# Altium schematic sheet ExtStm.SchDoc
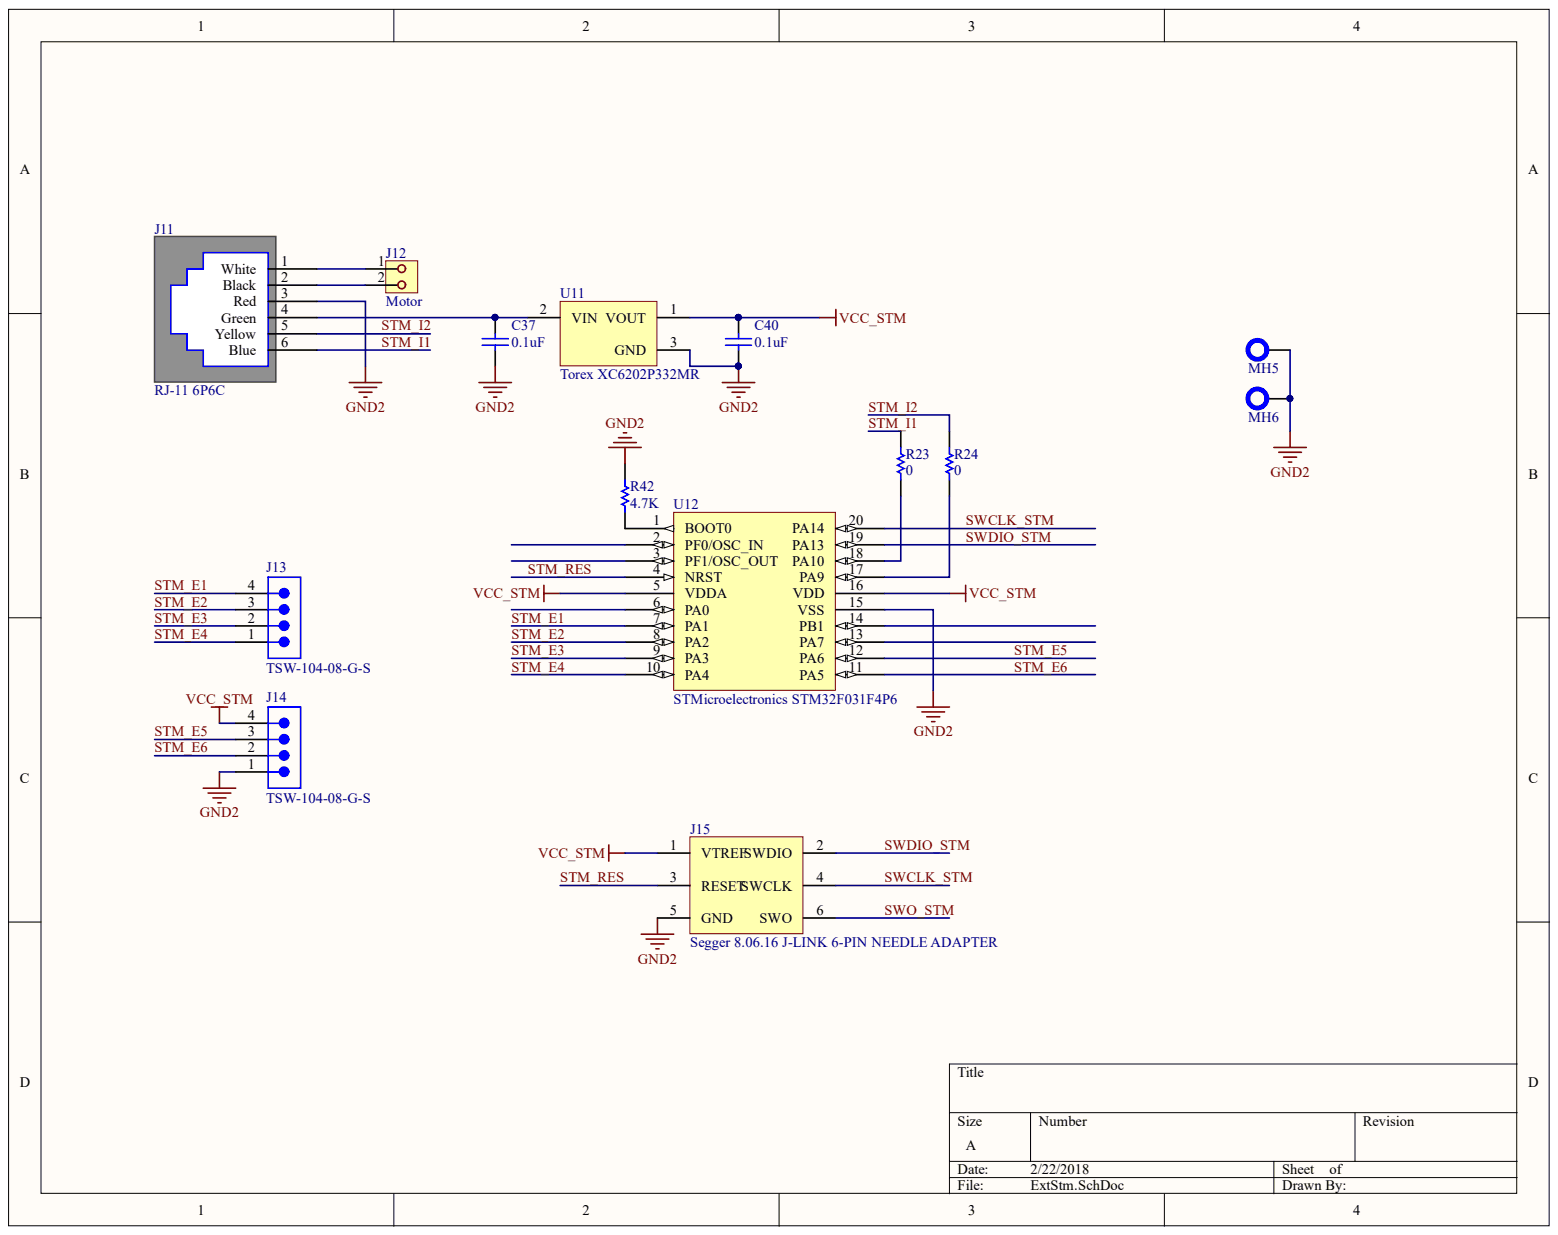
[diagram p0: ExtStm.SchDoc]

## Parts
C37  Capacitance: 0.1uF  [CAPC1608X90X35LL15T15]  (hidden comment: AVX Interconnect / Elco 06036D105KAT2A)
C40  Capacitance: 0.1uF  [CAPC1608X90X35LL15T15]  (hidden comment: AVX Interconnect / Elco 06036D105KAT2A)
J11  RJ-11 6P6C  [PCB-dhj0y0hoiu0232y63q4o-1]
J12  Motor  [PCB-55llon6gpk2h6a06nz0l-1]
J13  TSW-104-08-G-S  [SMTC-TSW-104-08-X-S]
J14  TSW-104-08-G-S  [SMTC-TSW-104-08-X-S]
J15  Segger 8.06.16 J-LINK 6-PIN NEEDLE ADAPTER  [PCB-ya76044v471n1vts2f97-1]
MH5  MountHole  [PCB-d0jqlyxt4wqhvgbnry4h-1]  (value not drawn)
MH6  MountHole  [PCB-d0jqlyxt4wqhvgbnry4h-1]  (value not drawn)
R23  Vishay Dale CRCW0603200KFKEA  [RESC1609X50X30ML10T20]  (value not drawn)
R24  Vishay Dale CRCW0603200KFKEA  [RESC1609X50X30ML10T20]  (value not drawn)
R42  Resistance: 4.7K  [RESC1609X50X30ML10T20]  (hidden comment: Vishay Dale CRCW0603200KFKEA)
U11  Torex XC6202P332MR  Manufacturer Part Number: XC6202P332MR  [PCB-0zngv7hxvzmz180uw1w7-1]
U12  STMicroelectronics STM32F031F4P6  [stm32f031f4p6]

## Nets
GND2: C37.1 C40.1 J11.3(Red) J14.1 J15.5(GND) MH5.1 MH6.1 R42.1 U11.3 U12.15(VSS)
VCC_STM: C40.2 J14.4 J15.1(VTREF) U11.1 U12.5(VDDA) U12.16(VDD)
STM_E1: J13.4 U12.7(PA1)
STM_E2: J13.3 U12.8(PA2)
STM_E3: J13.2 U12.9(PA3)
STM_E4: J13.1 U12.10(PA4)
STM_E5: J14.3 U12.12(PA6)
STM_E6: J14.2 U12.11(PA5)
STM_I1: J11.6(Blue) R23.2
STM_I2: J11.5(Yellow) R24.2
STM_RES: J15.3(RESET) U12.4(NRST)
SWCLK_STM: J15.4(SWCLK) U12.20(PA14)
SWDIO_STM: J15.2(SWDIO) U12.19(PA13)
SWO_STM: J15.6(SWO)
NetC37_2: C37.2 J11.4(Green) U11.2
NetJ11_1: J11.1(White) J12.1
NetJ11_2: J11.2(Black) J12.2
NetR23_1: R23.1 U12.18(PA10)
NetR24_1: R24.1 U12.17(PA9)
NetR42_2: R42.2 U12.1(BOOT0)

Not connected: U12 2(PF0/OSC_IN) 3(PF1/OSC_OUT) 6(PA0) 13(PA7) 14(PB1)

## Library data of the parts
C37 C40 = CMP-126022-2: CAP CER 1UF 6.3V 10% X5R 0603; Dielectric Material: Ceramic Multilayer; Insulation Resistance: 1e+11 Ω; Lead-Free Status: Lead Free; Capacitance Tolerance: ±10%; Case/Package: 0603; Component Kind: Standard; Dielectric Characteristic: X5R; Library Name: CommunityVault; Mounting Style: Surface Mount; Number of Pins: 2; OctopartID: 12fddbf79c04cd3a; Octopart Url: https://octopart.com/06036d105kat2a-avx+interconnect+%2F+elco-43935; REACH SVHC Compliance: No SVHC; RoHS: Compliant; Size-Height: 0.00086 m; Size-Length: 0.0016 m; Size-Thickness: 0.0008636 m; Size-Width: 0.00081 m; Voltage Rating (DC): 6.3 V
J11 = CMP-33ecfa0a2b0dec2b-2: Conn RJ-11 F 6 POS 1.02mm Solder RA Thru-Hole 6 Terminal 1 Port; Manufacturer Part Number: PT-J623SS-66GR; Component Kind: Standard; Library Name: CommunityVault; Lifecycle Status: Not Listed by Manufacturer; OctopartID: 33ecfa0a2b0dec2b; RoHS: Compliant
J12 = CMP-8fae46aeaafe5069-11: 2.54mm (.100") Pitch C-Grid III Header, Single Row, Vertical, 1 Circuits, Gold (Au) Plating; Manufacturer Part Number: 90120-0762; Contact Resistance: 0.02 ?; Current Rating: 3.0 A; Gender: Male; Glow Wire Compliant: Non-Compliant; Insulation Resistance: 5000000000.0 ?; Lead-Free Status: Lead Free; Number of Circuits: 1; Number of Contacts: 2; Pin Pitch: 0.00254 m; Voltage Rating (AC): 350.0 V; Component Kind: Standard; Contact Material: Brass; Contact Plating: Gold; Halogen Free Status: Not Reviewed; Housing Color: Black; Housing Material: Plastic; Library Name: CommunityVault; Lifecycle Status: Not Listed by Manufacturer; Mounting Style: Through Hole, Vertical; Number of Positions: 2; Number of Rows: 1; OctopartID: 8fae46aeaafe5069; Octopart URL: http://octopart.com/90120-0762-molex-655414; Orientation: Vertical; Packaging: Bag; RoHS: Compliant; Size-Height: 0.0025 m; Size-Length: 0.00508 m; Size-Width: 0.00254 m; Voltage Rating (DC): 350.0 V
J13 J14 = CMP-4501915-2: 0.025" SQ Post Header, Through-hole, Vertical, -55 to 125 degC, 2.54 mm Pitch, 4-Pin, Male, RoHS; Lead-Free Status: Lead Free; Number of Contacts: 4; Pin Pitch: 0.00254 m; Component Kind: Standard; Contact Material: Phosphor Bronze; Contact Plating: Gold; Gender: Male; Housing Color: Black; Housing Material: Plastic; Library Name: CommunityVault; Mounting Style: Through Hole; Number of Positions: 4; Number of Rows: 1; OctopartID: c7480e46cb27188f; Octopart Url: https://octopart.com/tsw-104-08-g-s-samtec-272649; REACH SVHC Compliance: No SVHC; RoHS: Compliant; Size-Height: 0.00254 m; Size-Length: 0.01016 m; Size-Width: 0.00254 m
J15 = CMP-fcd43101a04b2313-1: j-Link 6-Pin Needle Adapter; Component Kind: Standard; Library Name: CommunityVault; Octopart URL: http://octopart.com/8.06.16+j-link+6-pin+needle+adapter-segger-81754617
MH5 MH6 = CMP-eo2hwbm1pte8m6tn8ao3-1: M3 mounthole with space for 5mm dia stands; Component Kind: Standard; CustomComponentID: eo2hwbm1pte8m6tn8ao3; Library Name: CommunityVault
R23 R24 = CMP-1857245-4: RES SMD 200K OHM 1% 1/10W 0603; Lead-Free Status: Lead Free; Power Rating: 0.1 W; Resistance: 0; Temperature Coefficient: 100.0 ppm/°C; Voltage Rating (DC): 75.0 V; Case/Package: 0603; Component Kind: Standard; Library Name: CommunityVault; Mounting Style: Surface Mount; Number of Pins: 2; OctopartID: 353afe6f23fdeafd; Octopart Url: https://octopart.com/crcw0603200kfkea-vishay-39793569; REACH SVHC Compliance: No SVHC; Resistance Tolerance: ±1%; RoHS: Compliant; Size-Height: 0.00045 m; Size-Length: 0.00155 m; Size-Width: 0.00085 m
R42 = CMP-1857245-4: RES SMD 200K OHM 1% 1/10W 0603; Lead-Free Status: Lead Free; Power Rating: 0.1 W; Temperature Coefficient: 100.0 ppm/°C; Voltage Rating (DC): 75.0 V; Case/Package: 0603; Component Kind: Standard; Library Name: CommunityVault; Mounting Style: Surface Mount; Number of Pins: 2; OctopartID: 353afe6f23fdeafd; Octopart Url: https://octopart.com/crcw0603200kfkea-vishay-39793569; REACH SVHC Compliance: No SVHC; Resistance Tolerance: ±1%; RoHS: Compliant; Size-Height: 0.00045 m; Size-Length: 0.00155 m; Size-Width: 0.00085 m
U11 = CMP-f1f438acc0d52e33-1: V REG LDO +3.3V, SMD, 6202, SOT233; Voltage Regulator Type: Positive Fixed LDO; Voltage, Input Max: 20V; Voltage, Out...; Dropout Voltage: 670 mV; Number of Outputs: 1; Output Current: 150 mA; Output Voltage: 3.30 V; Component Kind: Standard; Library Name: CommunityVault; Mounting Style: Surface Mount; Number of Pins: 3; OctopartID: f1f438acc0d52e33; Octopart URL: http://octopart.com/xc6202p332mr-torex-5379143; REACH SVHC Compliance: No SVHC; RoHS: Compliant
U12 = CMP-e7942c96d5213853-4: STM32F Series 16 kB Flash 4 kB RAM 48 MHz 32-Bit Microcontroller - TSSOP-20; Component Kind: Standard; Library Name: CommunityVault
